# Altium schematic sheet page3_mcu.SchDoc(page3_mcu)
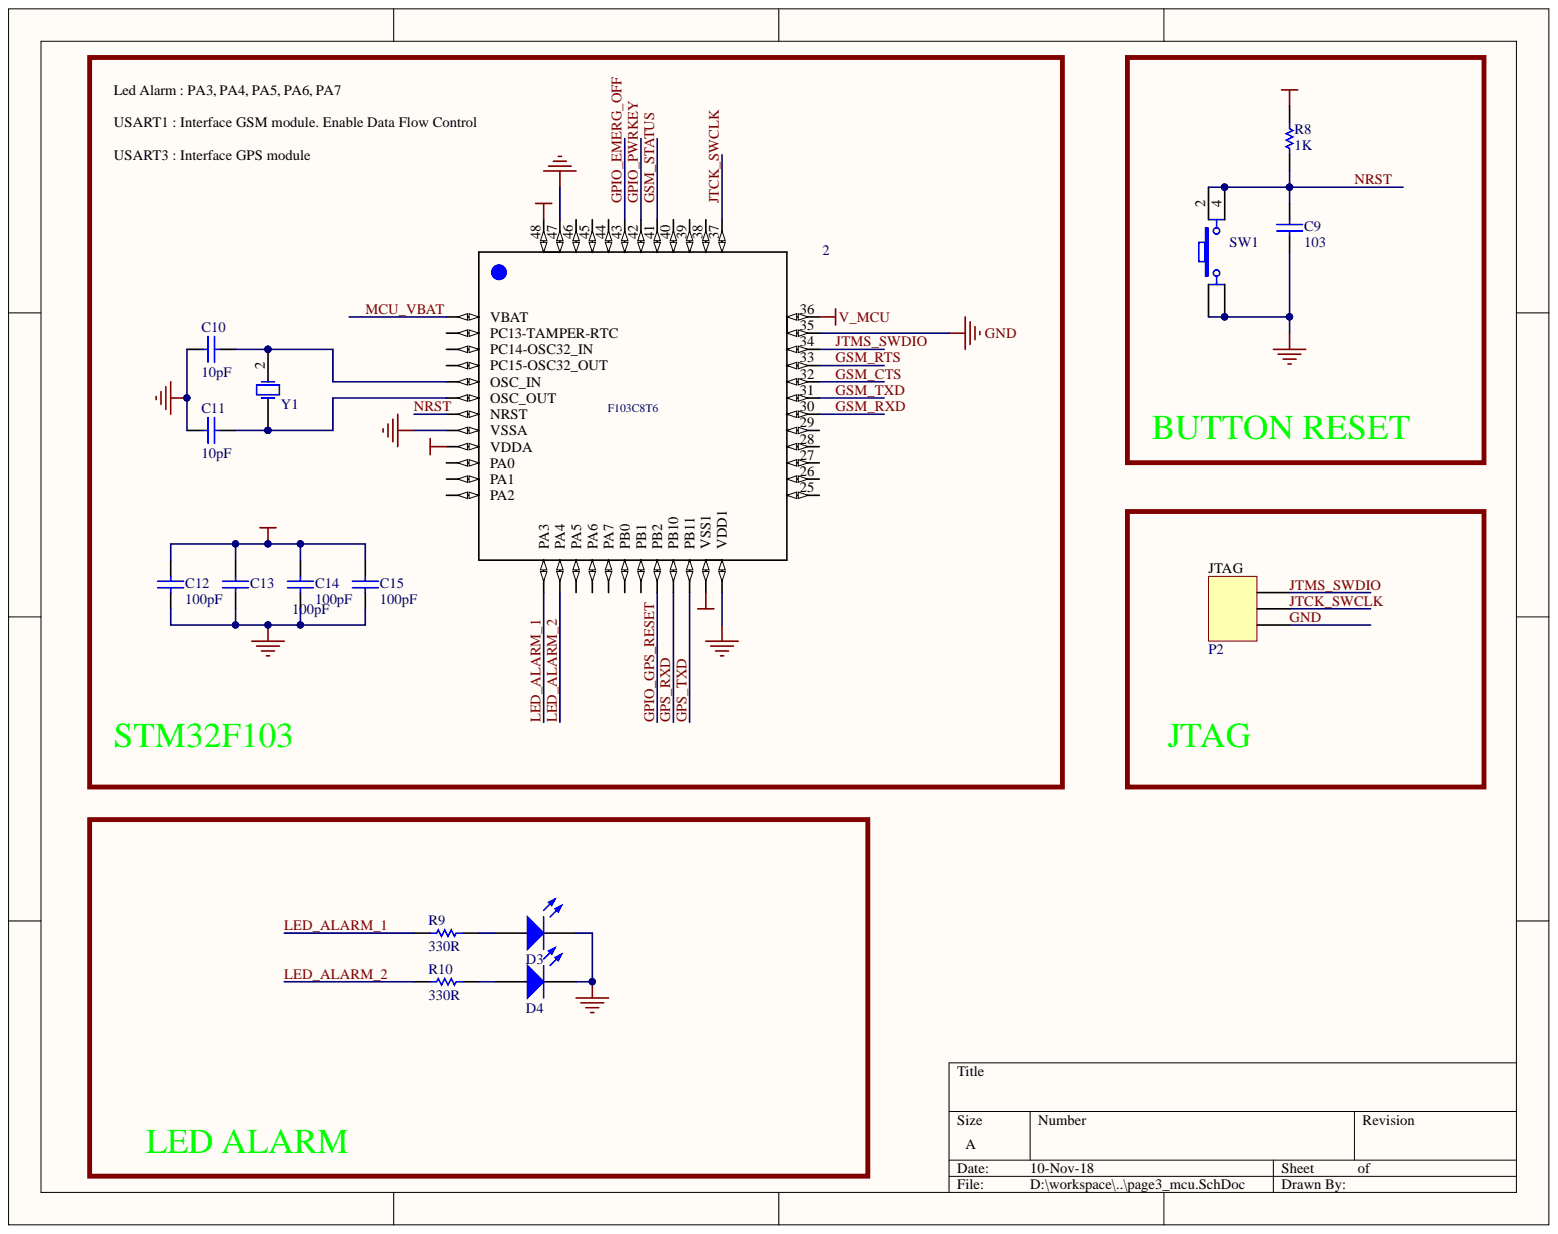
[diagram p0: page3_mcu.SchDoc]

## Parts
2  STM32F103C8T6  [LQFP48_7X7MM_0.5MMPP]  (value not drawn)
C9  103  [CAP-SM-0603]
C10  10pF  [CAP-SM-0603]
C11  10pF  [CAP-SM-0603]
C12  value 100pF  [CAP-SM-0603]  (hidden comment: Cap Non-Pol SMD)
C13  value 100pF  [CAP-SM-0603]  (hidden comment: Cap Non-Pol SMD)
C14  value 100pF  [CAP-SM-0603]  (hidden comment: Cap Non-Pol SMD)
C15  value 100pF  [CAP-SM-0603]  (hidden comment: Cap Non-Pol SMD)
D3  LED SMD  [LED_0603_Blue]  (value not drawn)
D4  LED SMD  [LED_0603_Blue]  (value not drawn)
P2  Header 3  [JP3]  (value not drawn)
R8  value 1K  [RES-SM-0603]  (hidden comment: RESISTOR SMD)
R9  value 330R  [RES-SM-0603]  (hidden comment: RESISTOR SMD)
R10  value 330R  [RES-SM-0603]  (hidden comment: RESISTOR SMD)
SW1  SW  [Tact SW 4 pin]
Y1  XTAL  [XTAL 32KN]  (value not drawn)

## Nets
V_MCU: 2.9(VDDA) 2.23(VSS1) 2.36 2.48 C12.2 C13.2 C14.2 C15.2 R8.2
GND: 2.8(VSSA) 2.24(VDD1) 2.35 2.47 C9.2 C10.2 C11.2 C12.1 C13.1 C14.1 C15.1 D3.2 D4.2 P2.1 SW1.1 SW1.3
GPIO_EMERG_OFF: 2.43
GPIO_GPS_RESET: 2.20(PB2)
GPIO_PWRKEY: 2.42
GPS_RXD: 2.21(PB10)
GPS_TXD: 2.22(PB11)
GSM_CTS: 2.32
GSM_RTS: 2.33
GSM_RXD: 2.30
GSM_STATUS: 2.41
GSM_TXD: 2.31
JTCK_SWCLK: 2.37 P2.2
JTMS_SWDIO: 2.34 P2.3
LED_ALARM_1: 2.13(PA3) R9.1
LED_ALARM_2: 2.14(PA4) R10.1
MCU_VBAT: 2.1(VBAT)
NRST: 2.7(NRST) C9.1 R8.1 SW1.2 SW1.4
Net2_5: 2.5(OSC_IN) C10.1 Y1.2
Net2_6: 2.6(OSC_OUT) C11.1 Y1.1
NetD3_1: D3.1 R9.2
NetD4_1: D4.1 R10.2

Not connected: 2 2(PC13-TAMPER-RTC) 3(PC14-OSC32_IN) 4(PC15-OSC32_OUT) 10(PA0) 11(PA1) 12(PA2) 15(PA5) 16(PA6) 17(PA7) 18(PB0) 19(PB1) 25 26 27 28 29 38 39 40 44 45 46

## Library data of the parts
2 = STM32F103C8T6: Mainstream Performance line, ARM Cortex-M3 MCU with 64 Kbytes Flash, 72 MHz CPU, motor control, USB and CAN; MF: STMicroelectronics; SnapEDA_Link: https://www.snapeda.com/parts/STM32F103C8T6/STMicroelectronics/view-part/?ref=snap; Price: None; Package: LQFP-48 STMicroelectronics; MP: STM32F103C8T6; Library Name: STM32F103C8T6.IntLib; Component Kind: Standard; Availability: Unavailable
C9-C15 = Cap Non-Pol SMD: Capacitor; Library Name: PIF_Library.IntLib; Component Kind: Standard
  C9: Value: 103
  C10 C11: Value: 10pF
D3 D4 = LED SMD: LED; Library Name: PIF_Library.IntLib; Component Kind: Standard
P2 = Header 3: Header, 3-Pin; Library Name: PIF_Library.IntLib; LatestRevisionNote: Re-released for DXP Platform.; LatestRevisionDate: 17-Jul-2002; Component Kind: Standard; Publisher: Altium Limited
R8-R10 = RESISTOR SMD: Resistor; Library Name: PIF_Library.IntLib; Component Kind: Standard
SW1 = Tact SW - 4Pin: Switch button 4 pin; Value: Button chip 4 pin; Library Name: PIF_Library.IntLib; Component Kind: Standard
Y1 = XTAL: Crystal Oscillator; Published: 8-Jun-2000; PackageReference: R38; PackageDescription: Crystal, Thru-Hole; 2 Leads; Body 3.1 x 8.2 mm (Dia.xH); Library Name: PIF_Library.IntLib; LatestRevisionNote: Re-released for DXP Platform.; LatestRevisionDate: 17-Jul-2002; Component Kind: Standard; Publisher: Altium Limited
